# Altium schematic sheet Filter_Amp.SchDoc(Filter_Amp)
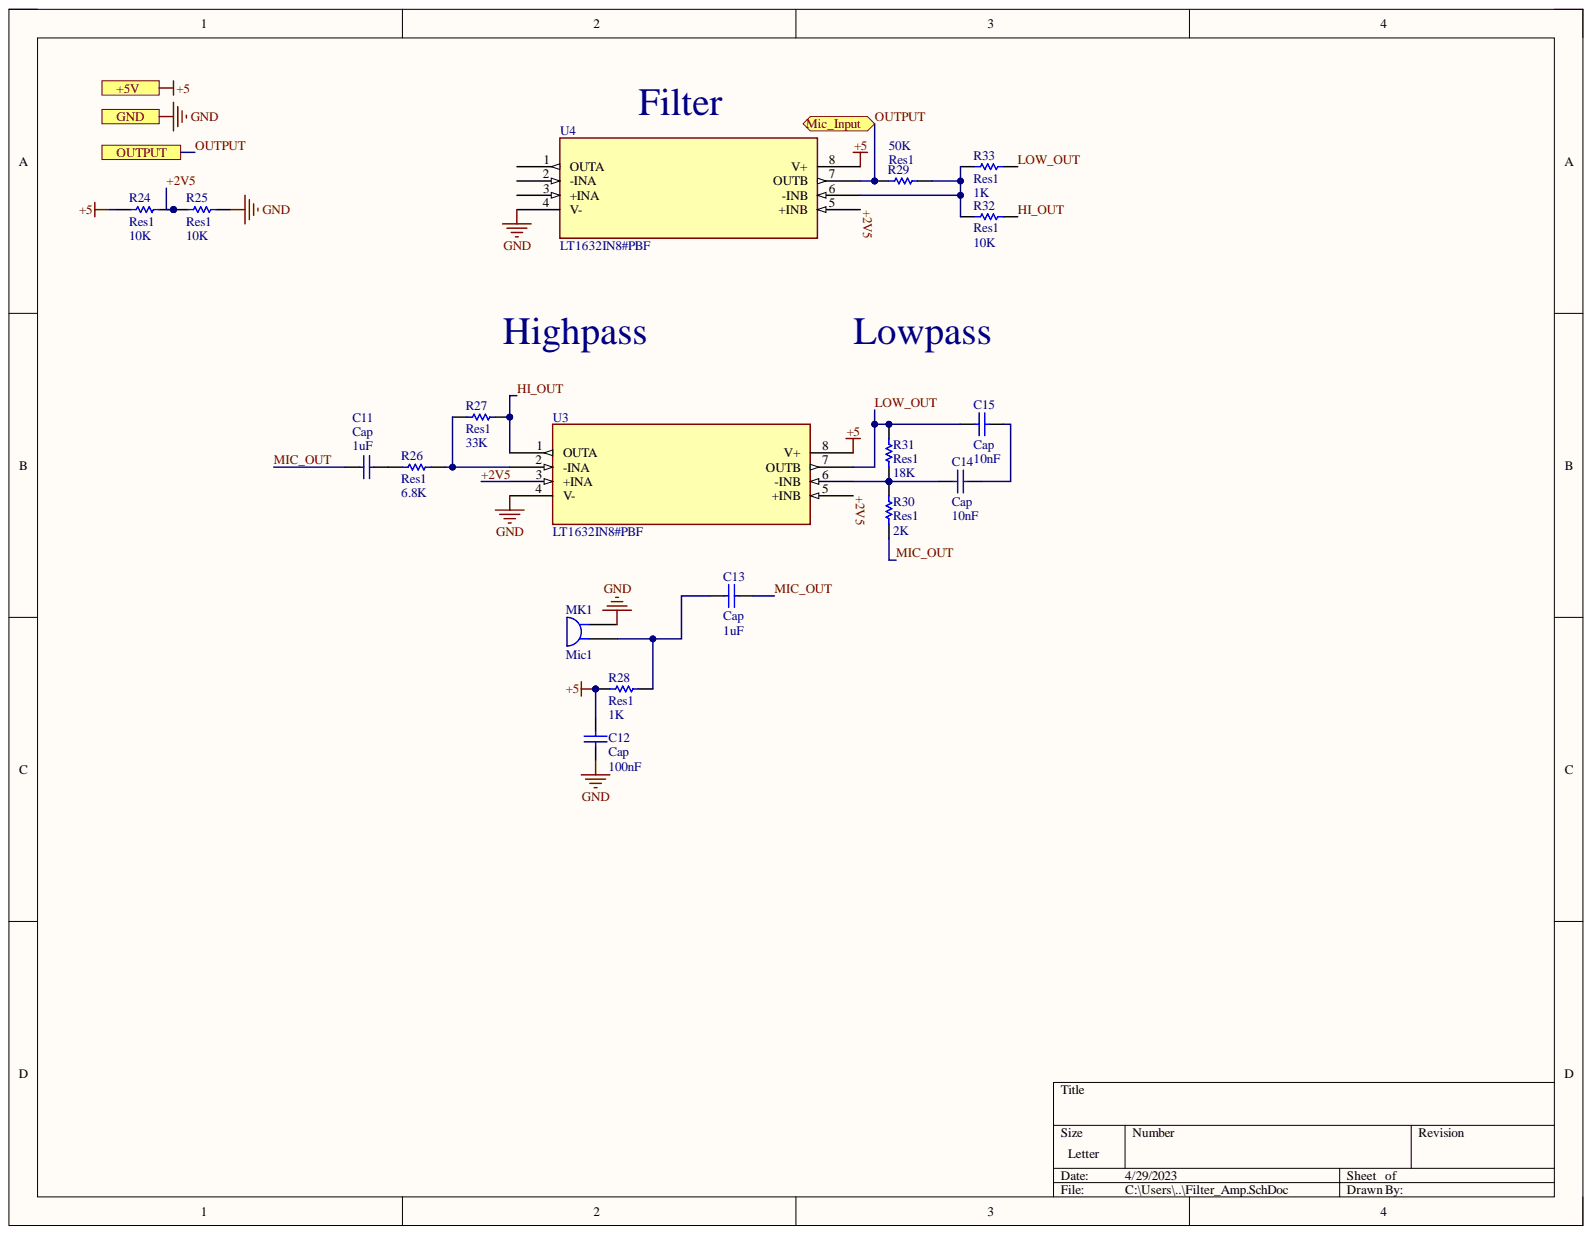
[diagram p0: Filter_Amp.SchDoc]

## Parts
C11  Cap  value 1uF  [CAPR5-4X5]
C12  Cap  value 100nF  [CAPR5-4X5]
C13  Cap  value 1uF  [CAPR5-4X5]
C14  Cap  value 10nF  [CAPR5-4X5]
C15  Cap  value 10nF  [CAPR5-4X5]
MK1  Mic1  [PIN2]
R24  Res1  value 10K  [AXIAL-0.3]
R25  Res1  value 10K  [AXIAL-0.3]
R26  Res1  value 6.8K  [AXIAL-0.3]
R27  Res1  value 33K  [AXIAL-0.3]
R28  Res1  value 1K  [AXIAL-0.3]
R29  Res1  value 50K  [AXIAL-0.3]
R30  Res1  value 2K  [AXIAL-0.3]
R31  Res1  value 18K  [AXIAL-0.3]
R32  Res1  value 10K  [AXIAL-0.3]
R33  Res1  value 1K  [AXIAL-0.3]
U3  LT1632IN8#PBF  [PDIP-8_N]
U4  LT1632IN8#PBF  [PDIP-8_N]

## Ports
+5V, GND, Mic_Input, OUTPUT

## Nets
+2V5: R24.2 R25.1 U3.3(+INA) U3.5(+INB) U4.5(+INB)
+5: C12.1 R24.1 R28.2 U3.8(V+) U4.8(V+) ; port +5V
GND: C12.2 MK1.2 R25.2 U3.4(V-) U4.4(V-) ; port GND
HI_OUT: R27.2 R32.2 U3.1(OUTA)
LOW_OUT: C15.2 R31.2 R33.2 U3.7(OUTB)
MIC_OUT: C11.2 C13.2 R30.2
OUTPUT: R29.1 U4.7(OUTB) ; port Mic_Input, port OUTPUT
NetC11_1: C11.1 R26.1
NetC13_1: C13.1 MK1.1 R28.1
NetC14_1: C14.1 C15.1
NetC14_2: C14.2 R30.1 R31.1 U3.6(-INB)
NetR26_2: R26.2 R27.1 U3.2(-INA)
NetR29_2: R29.2 R32.1 R33.1 U4.6(-INB)

Not connected: U4 1(OUTA) 2(-INA) 3(+INA)

## Library data of the parts
C11-C14 = Cap: Capacitor; Component Kind: Standard; LatestRevisionDate: 17-Jul-2002; LatestRevisionNote: Re-released for DXP Platform.; Library Name: Miscellaneous Devices.IntLib; PackageDescription: Radial Cap, Thru-Hole; 2 Leads; 0.3 in Pin Spacing; PackageReference: RAD-0.3; Published: 8-Jun-2000; Signal Integrity: Cap; Simulation: CAP; Publisher: Altium Limited
C15 = Cap: Capacitor; Value: 10nF; Component Kind: Standard; LatestRevisionDate: 17-Jul-2002; LatestRevisionNote: Re-released for DXP Platform.; Library Name: Miscellaneous Devices.IntLib; PackageDescription: Radial Cap, Thru-Hole; 2 Leads; 0.3 in Pin Spacing; PackageReference: RAD-0.3; Published: 8-Jun-2000; Signal Integrity: Cap; Simulation: CAP; Publisher: Altium Limited
MK1 = Mic1: Microphone; Component Kind: Standard; LatestRevisionDate: 17-Jul-2002; LatestRevisionNote: Re-released for DXP Platform.; Library Name: Miscellaneous Devices.IntLib; PackageReference: PIN2; Published: 24-Mar-1999; Publisher: Altium Limited
R24-R27 R29-R32 = Res1: Resistor; Component Kind: Standard; LatestRevisionDate: 17-Jul-2002; LatestRevisionNote: Re-released for DXP Platform.; Library Name: Miscellaneous Devices.IntLib; PackageDescription: Axial Device, Thru-Hole; 2 Leads; 0.3 in Pin Spacing; PackageReference: AXIAL-0.3; Published: 8-Jun-2000; Signal Integrity: Res; Simulation: RESISTOR; Publisher: Altium Limited
R28 R33 = Res1: Resistor; Value: 1K; Component Kind: Standard; LatestRevisionDate: 17-Jul-2002; LatestRevisionNote: Re-released for DXP Platform.; Library Name: Miscellaneous Devices.IntLib; PackageDescription: Axial Device, Thru-Hole; 2 Leads; 0.3 in Pin Spacing; PackageReference: AXIAL-0.3; Published: 8-Jun-2000; Signal Integrity: Res; Simulation: RESISTOR; Publisher: Altium Limited
U3 U4 = LT1632IN8#PBF: 45MHz, 45V/Âµs, Dual Rail-to-Rail Input and Output Precision Op Amps; MF: Analog Devices; Availability: In Stock; Check_prices: https://www.snapeda.com/parts/LT1632IN8%23PBF/Analog+Devices/view-part/?ref=eda; Component Kind: Standard; Library Name: LT1632IN8_PBF.IntLib; MP: LT1632IN8#PBF; Package: PDIP -8 Analog Devices; Price: None; Purchase-URL: https://pricing.snapeda.com/search/part/LT1632IN8#PBF/?ref=eda; SnapEDA_Link: https://www.snapeda.com/parts/LT1632IN8%23PBF/Analog+Devices/view-part/?ref=snap
